# Altium schematic sheet C1.SchDoc(C1)
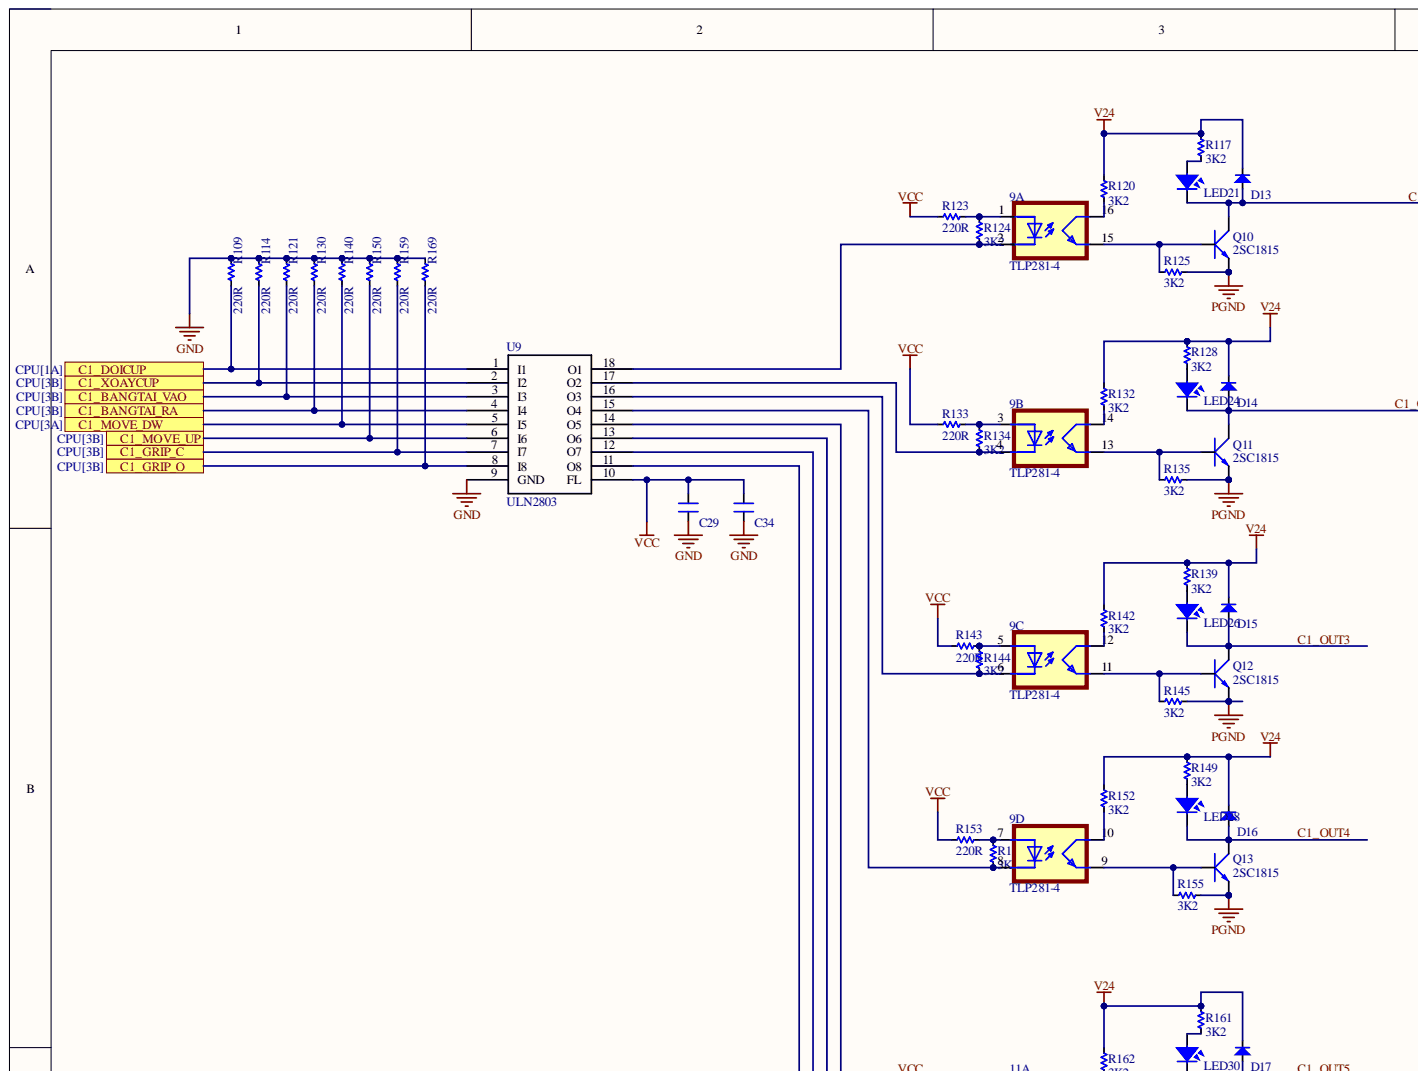
[diagram p0: C1.SchDoc, top left]
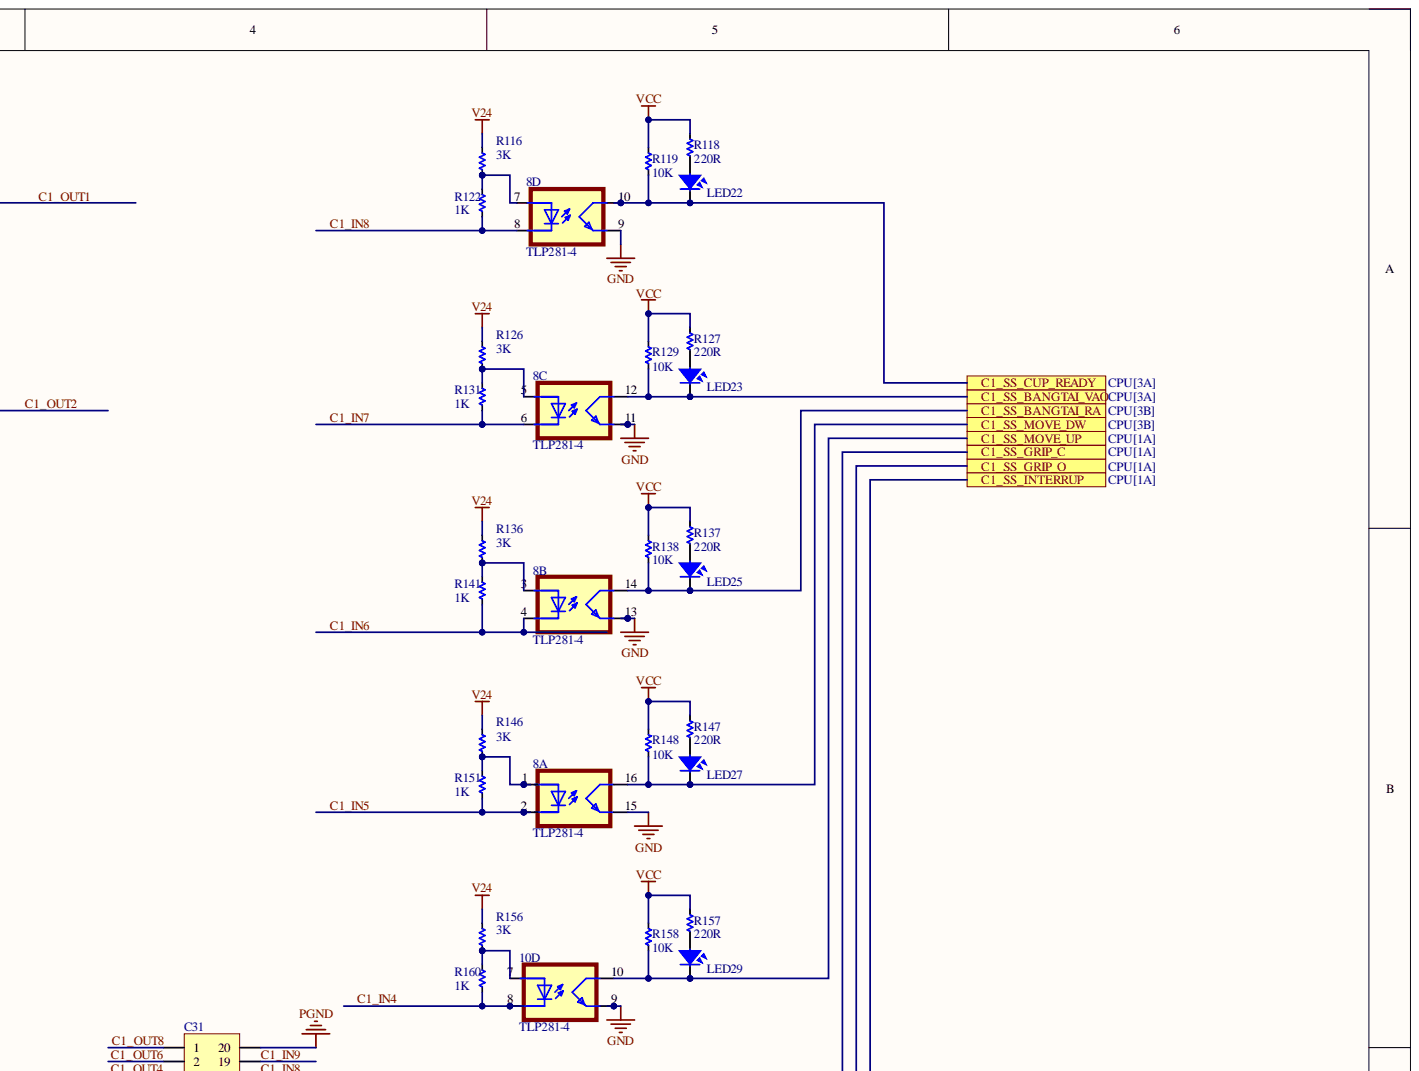
[diagram p1: C1.SchDoc, top right]
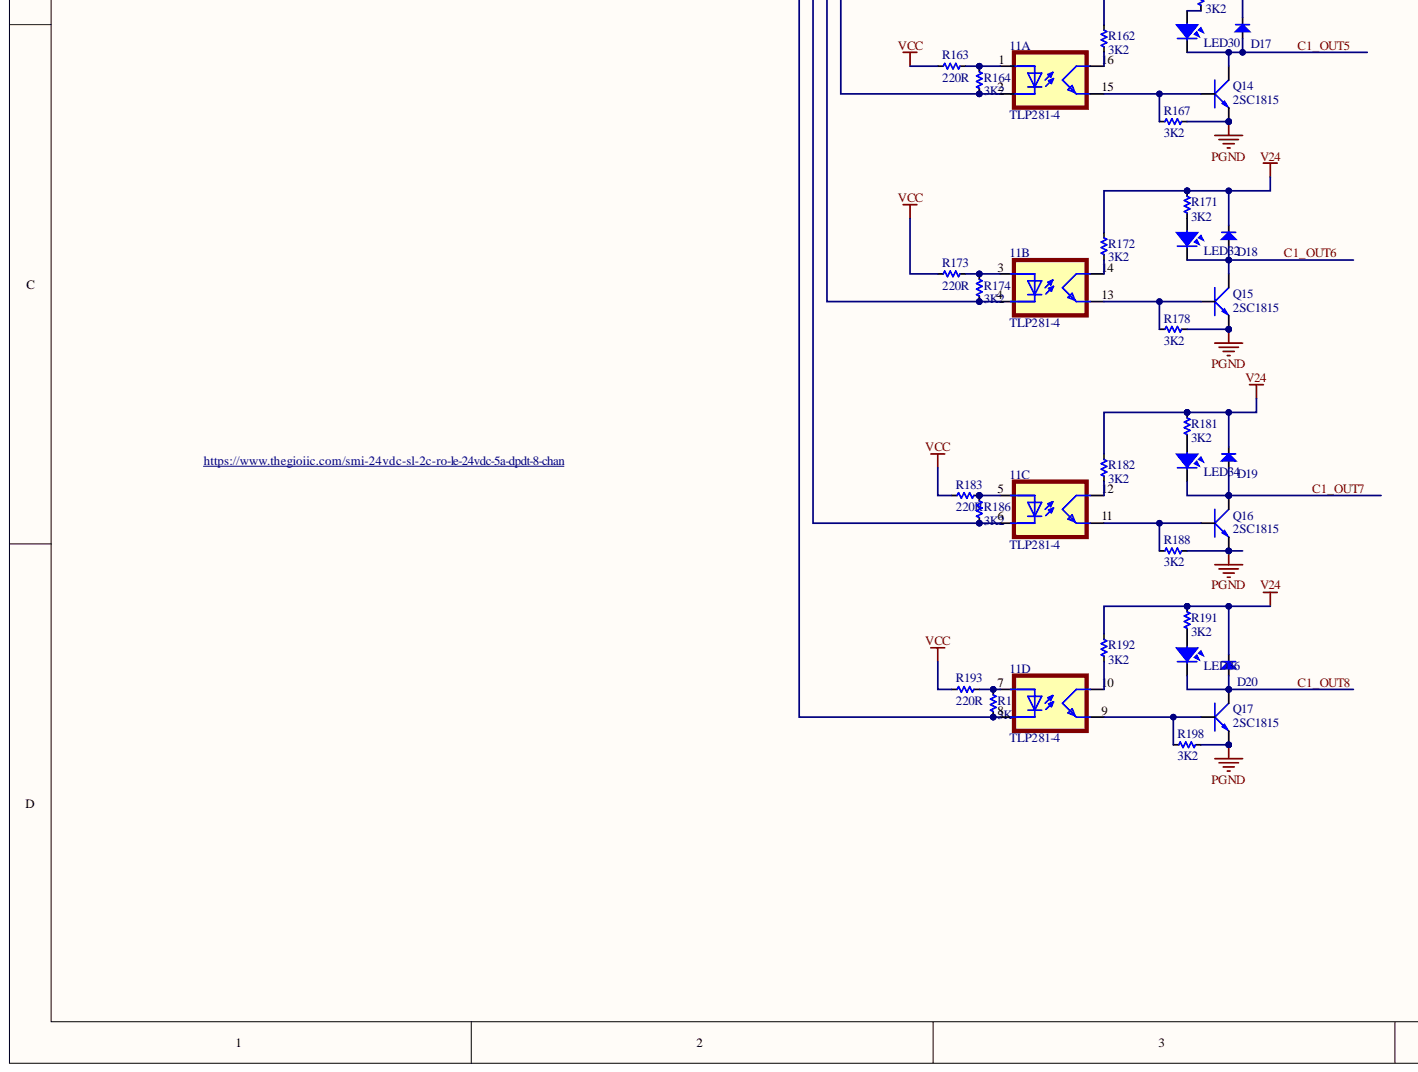
[diagram p2: C1.SchDoc, bottom left]
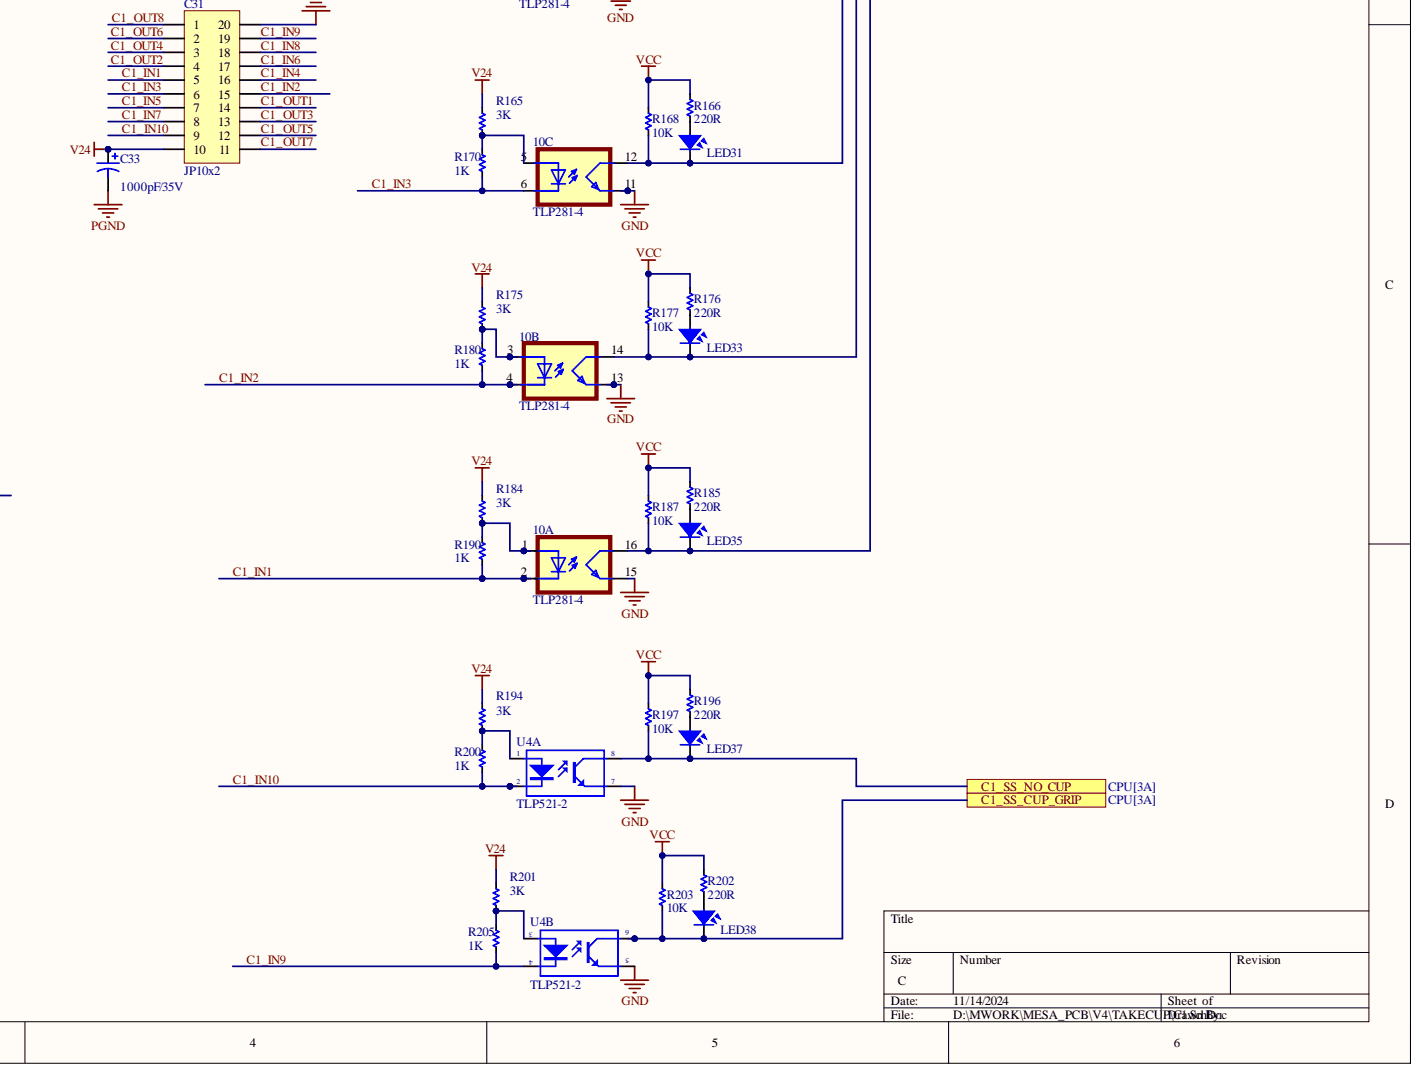
[diagram p3: C1.SchDoc, bottom right]

## Parts
8  TLP281-4  [SOP-16]  (parts A,B,C,D)
9  TLP281-4  [SOP-16]  (parts A,B,C,D)
10  TLP281-4  [SOP-16]  (parts A,B,C,D)
11  TLP281-4  [SOP-16]  (parts A,B,C,D)
C29  100nF  [CN-SMD-0805]  (value not drawn)
C31  JP10x2  [Connecter 5569]
C33  Cap Pol3  [CP2/5]  (value not drawn)
C34  100nF  [CN-SMD-0805]  (value not drawn)
D13  Diode  [DIO-SMD-106-HayDung]  (value not drawn)
D14  Diode  [DIO-SMD-106-HayDung]  (value not drawn)
D15  Diode  [DIO-SMD-106-HayDung]  (value not drawn)
D16  Diode  [DIO-SMD-106-HayDung]  (value not drawn)
D17  Diode  [DIO-SMD-106-HayDung]  (value not drawn)
D18  Diode  [DIO-SMD-106-HayDung]  (value not drawn)
D19  Diode  [DIO-SMD-106-HayDung]  (value not drawn)
D20  Diode  [DIO-SMD-106-HayDung]  (value not drawn)
LED21  LED  [LED-0805-Blue]
LED22  LED  [LED-0805-Blue]
LED23  LED  [LED-0805-Blue]
LED24  LED  [LED-0805-Blue]
LED25  LED  [LED-0805-Blue]
LED26  LED  [LED-0805-Blue]
LED27  LED  [LED-0805-Blue]
LED28  LED  [LED-0805-Blue]
LED29  LED  [LED-0805-Blue]
LED30  LED  [LED-0805-Blue]
LED31  LED  [LED-0805-Blue]
LED32  LED  [LED-0805-Blue]
LED33  LED  [LED-0805-Blue]
LED34  LED  [LED-0805-Blue]
LED35  LED  [LED-0805-Blue]
LED36  LED  [LED-0805-Blue]
LED37  LED  [LED-0805-Blue]
LED38  LED  [LED-0805-Blue]
Q10  2SC1815  [SOT23-321]
Q11  2SC1815  [SOT23-321]
Q12  2SC1815  [SOT23-321]
Q13  2SC1815  [SOT23-321]
Q14  2SC1815  [SOT23-321]
Q15  2SC1815  [SOT23-321]
Q16  2SC1815  [SOT23-321]
Q17  2SC1815  [SOT23-321]
R109  220R  [RES-SMD-0805]
R114  220R  [RES-SMD-0805]
R116  3K  [RES-SMD-1206]
R117  3K2  [RES-SMD-0805]
R118  220R  [RES-SMD-0805]
R119  10K  [RES-SMD-0805]
R120  3K2  [RES-SMD-0805]
R121  220R  [RES-SMD-0805]
R122  1K  [RES-SMD-0805]
R123  220R  [RES-SMD-0805]
R124  3K2  [RES-SMD-0805]
R125  3K2  [RES-SMD-0805]
R126  3K  [RES-SMD-1206]
R127  220R  [RES-SMD-0805]
R128  3K2  [RES-SMD-0805]
R129  10K  [RES-SMD-0805]
R130  220R  [RES-SMD-0805]
R131  1K  [RES-SMD-0805]
R132  3K2  [RES-SMD-0805]
R133  220R  [RES-SMD-0805]
R134  3K2  [RES-SMD-0805]
R135  3K2  [RES-SMD-0805]
R136  3K  [RES-SMD-1206]
R137  220R  [RES-SMD-0805]
R138  10K  [RES-SMD-0805]
R139  3K2  [RES-SMD-0805]
R140  220R  [RES-SMD-0805]
R141  1K  [RES-SMD-0805]
R142  3K2  [RES-SMD-0805]
R143  220R  [RES-SMD-0805]
R144  3K2  [RES-SMD-0805]
R145  3K2  [RES-SMD-0805]
R146  3K  [RES-SMD-1206]
R147  220R  [RES-SMD-0805]
R148  10K  [RES-SMD-0805]
R149  3K2  [RES-SMD-0805]
R150  220R  [RES-SMD-0805]
R151  1K  [RES-SMD-0805]
R152  3K2  [RES-SMD-0805]
R153  220R  [RES-SMD-0805]
R154  3K2  [RES-SMD-0805]
R155  3K2  [RES-SMD-0805]
R156  3K  [RES-SMD-1206]
R157  220R  [RES-SMD-0805]
R158  10K  [RES-SMD-0805]
R159  220R  [RES-SMD-0805]
R160  1K  [RES-SMD-0805]
R161  3K2  [RES-SMD-0805]
R162  3K2  [RES-SMD-0805]
R163  220R  [RES-SMD-0805]
R164  3K2  [RES-SMD-0805]
R165  3K  [RES-SMD-1206]
R166  220R  [RES-SMD-0805]
R167  3K2  [RES-SMD-0805]
R168  10K  [RES-SMD-0805]
R169  220R  [RES-SMD-0805]
R170  1K  [RES-SMD-0805]
R171  3K2  [RES-SMD-0805]
R172  3K2  [RES-SMD-0805]
R173  220R  [RES-SMD-0805]
R174  3K2  [RES-SMD-0805]
R175  3K  [RES-SMD-1206]
R176  220R  [RES-SMD-0805]
R177  10K  [RES-SMD-0805]
R178  3K2  [RES-SMD-0805]
R180  1K  [RES-SMD-0805]
R181  3K2  [RES-SMD-0805]
R182  3K2  [RES-SMD-0805]
R183  220R  [RES-SMD-0805]
R184  3K  [RES-SMD-1206]
R185  220R  [RES-SMD-0805]
R186  3K2  [RES-SMD-0805]
R187  10K  [RES-SMD-0805]
R188  3K2  [RES-SMD-0805]
R190  1K  [RES-SMD-0805]
R191  3K2  [RES-SMD-0805]
R192  3K2  [RES-SMD-0805]
R193  220R  [RES-SMD-0805]
R194  3K  [RES-SMD-1206]
R195  3K2  [RES-SMD-0805]
R196  220R  [RES-SMD-0805]
R197  10K  [RES-SMD-0805]
R198  3K2  [RES-SMD-0805]
R200  1K  [RES-SMD-0805]
R201  3K  [RES-SMD-1206]
R202  220R  [RES-SMD-0805]
R203  10K  [RES-SMD-0805]
R205  1K  [RES-SMD-0805]
U4  TLP521-2  [DIP8/W0.3]  (parts A,B)
U9  ULN2803  [SO18]

## Ports
C1_BANGTAI_RA, C1_BANGTAI_VAO, C1_DOICUP, C1_GRIP_C, C1_GRIP_O, C1_MOVE_DW, C1_MOVE_UP, C1_SS_BANGTAI_RA, C1_SS_BANGTAI_VAO, C1_SS_CUP_GRIP, C1_SS_CUP_READY, C1_SS_GRIP_C, C1_SS_GRIP_O, C1_SS_INTERRUP, C1_SS_MOVE_DW, C1_SS_MOVE_UP, C1_SS_NO_CUP, C1_XOAYCUP

## Nets
GND: 8.9 8.11 8.13 8.15 10.9 10.11 10.13 10.15 C29.2 C34.2 R109.2 R114.2 R121.2 R130.2 R140.2 R150.2 R159.2 R169.2 U4.5 U4.7 U9.9(GND)
PGND: C31.20 C33.2 Q10.1 Q11.1 Q12.1 Q13.1 Q14.1 Q15.1 Q16.1 Q17.1 R125.1 R135.2 R145.1 R155.1 R167.1 R178.2 R188.1 R198.1
V24: C31.10 C33.1 D13.2 D14.2 D15.2 D16.2 D17.2 D18.2 D19.2 D20.2 R116.2 R117.1 R120.2 R126.2 R128.1 R132.2 R136.2 R139.1 R142.2 R146.2 R149.1 R152.2 R156.2 R161.1 R162.2 R165.2 R171.1 R172.2 R175.2 R181.1 R182.2 R184.2 R191.1 R192.2 R194.2 R201.2
VCC: C29.1 C34.1 R118.1 R119.2 R123.1 R127.1 R129.2 R133.1 R137.1 R138.2 R143.1 R147.1 R148.2 R153.1 R157.1 R158.2 R163.1 R166.1 R168.2 R173.1 R176.1 R177.2 R183.1 R185.1 R187.2 R193.1 R196.1 R197.2 R202.1 R203.2 U9.10(FL)
C1_BANGTAI_RA: R130.1 U9.4(I4) ; port C1_BANGTAI_RA
C1_BANGTAI_VAO: R121.1 U9.3(I3) ; port C1_BANGTAI_VAO
C1_DOICUP: R109.1 U9.1(I1) ; port C1_DOICUP
C1_GRIP_C: R159.1 U9.7(I7) ; port C1_GRIP_C
C1_GRIP_O: R169.1 U9.8(I8) ; port C1_GRIP_O
C1_IN1: 10.2 C31.5 R190.2
C1_IN2: 10.4 C31.15 R180.2
C1_IN3: 10.6 C31.6 R170.2
C1_IN4: 10.8 C31.16 R160.2
C1_IN5: 8.2 C31.7 R151.2
C1_IN6: 8.4 C31.17 R141.2
C1_IN7: 8.6 C31.8 R131.2
C1_IN8: 8.8 C31.18 R122.2
C1_IN9: C31.19 R205.2 U4.4
C1_IN10: C31.9 R200.2 U4.2
C1_MOVE_DW: R140.1 U9.5(I5) ; port C1_MOVE_DW
C1_MOVE_UP: R150.1 U9.6(I6) ; port C1_MOVE_UP
C1_OUT1: C31.14 D13.1 LED21.2 Q10.2
C1_OUT2: C31.4 D14.1 LED24.2 Q11.2
C1_OUT3: C31.13 D15.1 LED26.2 Q12.2
C1_OUT4: C31.3 D16.1 LED28.2 Q13.2
C1_OUT5: C31.12 D17.1 LED30.2 Q14.2
C1_OUT6: C31.2 D18.1 LED32.2 Q15.2
C1_OUT7: C31.11 D19.1 LED34.2 Q16.2
C1_OUT8: C31.1 D20.1 LED36.2 Q17.2
C1_SS_BANGTAI_RA: 8.14 LED25.2 R138.1 ; port C1_SS_BANGTAI_RA
C1_SS_BANGTAI_VAO: 8.12 LED23.2 R129.1 ; port C1_SS_BANGTAI_VAO
C1_SS_CUP_GRIP: LED38.2 R203.1 U4.6 ; port C1_SS_CUP_GRIP
C1_SS_CUP_READY: 8.10 LED22.2 R119.1 ; port C1_SS_CUP_READY
C1_SS_GRIP_C: 10.12 LED31.2 R168.1 ; port C1_SS_GRIP_C
C1_SS_GRIP_O: 10.14 LED33.2 R177.1 ; port C1_SS_GRIP_O
C1_SS_INTERRUP: 10.16 LED35.2 R187.1 ; port C1_SS_INTERRUP
C1_SS_MOVE_DW: 8.16 LED27.2 R148.1 ; port C1_SS_MOVE_DW
C1_SS_MOVE_UP: 10.10 LED29.2 R158.1 ; port C1_SS_MOVE_UP
C1_SS_NO_CUP: LED37.2 R197.1 U4.8 ; port C1_SS_NO_CUP
C1_XOAYCUP: R114.1 U9.2(I2) ; port C1_XOAYCUP
Net8_1: 8.1 R146.1 R151.1
Net8_3: 8.3 R136.1 R141.1
Net8_5: 8.5 R126.1 R131.1
Net8_7: 8.7 R116.1 R122.1
Net9_1: 9.1 R123.2 R124.2
Net9_2: 9.2 R124.1 U9.18(O1)
Net9_3: 9.3 R133.2 R134.2
Net9_4: 9.4 R134.1 U9.17(O2)
Net9_5: 9.5 R143.2 R144.2
Net9_6: 9.6 R144.1 U9.16(O3)
Net9_7: 9.7 R153.2 R154.2
Net9_8: 9.8 R154.1 U9.15(O4)
Net9_9: 9.9 Q13.3 R155.2
Net9_10: 9.10 R152.1
Net9_11: 9.11 Q12.3 R145.2
Net9_12: 9.12 R142.1
Net9_13: 9.13 Q11.3 R135.1
Net9_14: 9.14 R132.1
Net9_15: 9.15 Q10.3 R125.2
Net9_16: 9.16 R120.1
Net10_1: 10.1 R184.1 R190.1
Net10_3: 10.3 R175.1 R180.1
Net10_5: 10.5 R165.1 R170.1
Net10_7: 10.7 R156.1 R160.1
Net11_1: 11.1 R163.2 R164.2
Net11_2: 11.2 R164.1 U9.14(O5)
Net11_3: 11.3 R173.2 R174.2
Net11_4: 11.4 R174.1 U9.13(O6)
Net11_5: 11.5 R183.2 R186.2
Net11_6: 11.6 R186.1 U9.12(O7)
Net11_7: 11.7 R193.2 R195.2
Net11_8: 11.8 R195.1 U9.11(O8)
Net11_9: 11.9 Q17.3 R198.2
Net11_10: 11.10 R192.1
Net11_11: 11.11 Q16.3 R188.2
Net11_12: 11.12 R182.1
Net11_13: 11.13 Q15.3 R178.1
Net11_14: 11.14 R172.1
Net11_15: 11.15 Q14.3 R167.2
Net11_16: 11.16 R162.1
NetLED21_1: LED21.1 R117.2
NetLED22_1: LED22.1 R118.2
NetLED23_1: LED23.1 R127.2
NetLED24_1: LED24.1 R128.2
NetLED25_1: LED25.1 R137.2
NetLED26_1: LED26.1 R139.2
NetLED27_1: LED27.1 R147.2
NetLED28_1: LED28.1 R149.2
NetLED29_1: LED29.1 R157.2
NetLED30_1: LED30.1 R161.2
NetLED31_1: LED31.1 R166.2
NetLED32_1: LED32.1 R171.2
NetLED33_1: LED33.1 R176.2
NetLED34_1: LED34.1 R181.2
NetLED35_1: LED35.1 R185.2
NetLED36_1: LED36.1 R191.2
NetLED37_1: LED37.1 R196.2
NetLED38_1: LED38.1 R202.2
NetR194_1: R194.1 R200.1 U4.1
NetR201_1: R201.1 R205.1 U4.3

## Library data of the parts
8-11 = TLP281-4: Optoisolator Transistor Output 2500Vrms 4 Channel 16-SOP; MF: Toshiba Semiconductor; MP: TLP 280-4; Availability: Unavailable; Component Kind: Standard; Package: SOP-16 Toshiba; Price: None; SnapEDA_Link: https://www.snapeda.com/parts/TLP%20280-4/Toshiba%20Semiconductor%20and%20Storage/view-part/108247/?ref=snap; Library Name: nhan.SchLib
C29 C34 = CN: Value: 33pF; Component Kind: Standard; Library Name: nhan.SchLib
C31 = JP10x2: WR-PHD 2.54 mm Dual Socket Header; Manufacturer: W¨¹rth Elektronik eiSos GmbH; partNo: 61302021821; ComponentLink1 Description: Manufacturer; ComponentLink2 Description: Datasheet; NoPins: 20; Component Kind: Standard; ComponentLink1URL: http://www.we-online.com; ComponentLink2URL: http://katalog.we-online.de/em/datasheet/6130xx21821.pdf; Created Date: 29 March 2013; Created by: Karrer Zheng; Library Name: nhan.SchLib
C33 = Cap Pol3_5: Polarized Capacitor (Surface Mount); VALUE: C?; Component Kind: Standard; LatestRevisionDate: 17-Jul-2002; LatestRevisionNote: Re-released for DXP Platform.; PackageReference: C0805; Library Name: syhaunguyen.IntLib; Publisher: Altium Limited
D13-D20 = Diode: Default Diode; Code - JEDEC: DO-214-AB; Value: 1N5007; Component Kind: Standard; ComponentLink1Description: ES1J - STMBL; ComponentLink1URL: https://banlinhkien.vn/goods-8089-es1j-do214-diode-1a-600v-sma-es1j-.html; Published: 8-Jun-2000; Revision: July-2002: Re-released for DXP Platform.; Library Name: nhan.SchLib; Publisher: Altium Limited
LED21-LED38 = LED SMD: Value: LED 3 - 5 ly 2 Colour; Component Kind: Standard; Library Name: LIBRARY.IntLib
Q10-Q17 = 2SC1815: NPN 600mW, ECB; Value: C1815; Component Kind: Standard; Library Name: LIBRARY.IntLib
R109 R114 R117-R121 R123-R125 R127-R130 R132-R135 R137-R140 R142-R145 R147-R150 R152-R155 R157-R159 R161-R164 R166-R169 R171-R174 R176-R178 R181-R183 R185-R188 R191-R193 R195-R198 R202 R203 = R: Component Kind: Standard; Library Name: nhan.SchLib
R116 R122 R126 R131 R136 R141 R146 R151 R156 R160 R165 R170 R175 R180 R184 R190 R194 R200 R201 R205 = Res-SMD: Resistor; Manufacturer: Generic Components; Class: Passive; Component Kind: Standard; Library Name: LIBRARY.IntLib; Published: 08/06/2000; Publisher: Altium Hobart Technology Centre
U4 = TLP521-2: Dual Opto; Component Kind: Standard; Value: TLP521-2; Library Name: syhaunguyen.IntLib
U9 = ULN2803: OCTAL HIGH CURRENT DRIVER; Manufacturer: Texas Instruments; Manufacturer Part Number: ULN2803ADWR; Number of Drivers/Receivers: 8/0; Voltage - Supply: 5V; Category: Integrated Circuits (ICs); Component Kind: Standard; Library Name: LIBRARY.IntLib; Package / Case: 18-SOIC (7.5mm Width); Packaging: Cut Tape (CT); Protocol: -; RoHS: RoHS Compliant; Supplier: Digi-Key; Supplier 1: Digi-Key; Supplier Part Number: 296-15777-1-ND; Supplier Part Number 1: 296-15777-1-ND; Type: Darlington Array
